# Altium schematic sheet DE-100224_01.SchDoc(DE-100224_01)
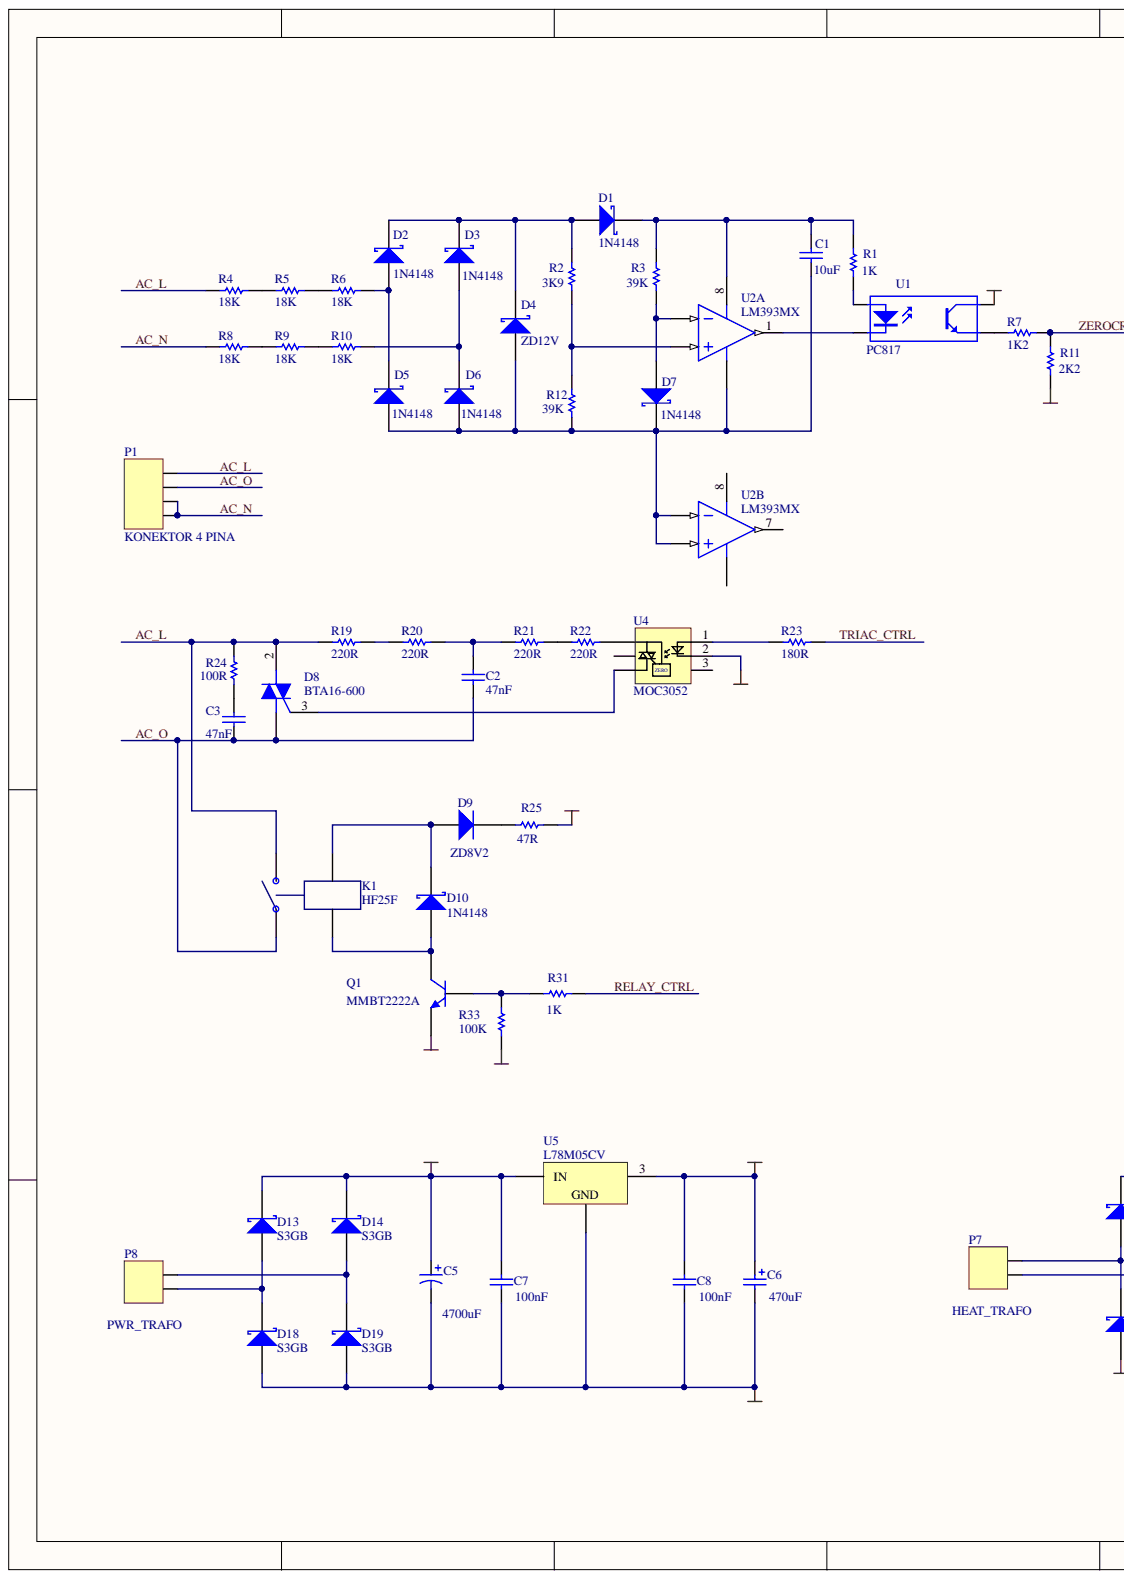
[diagram p0: DE-100224_01.SchDoc, left]
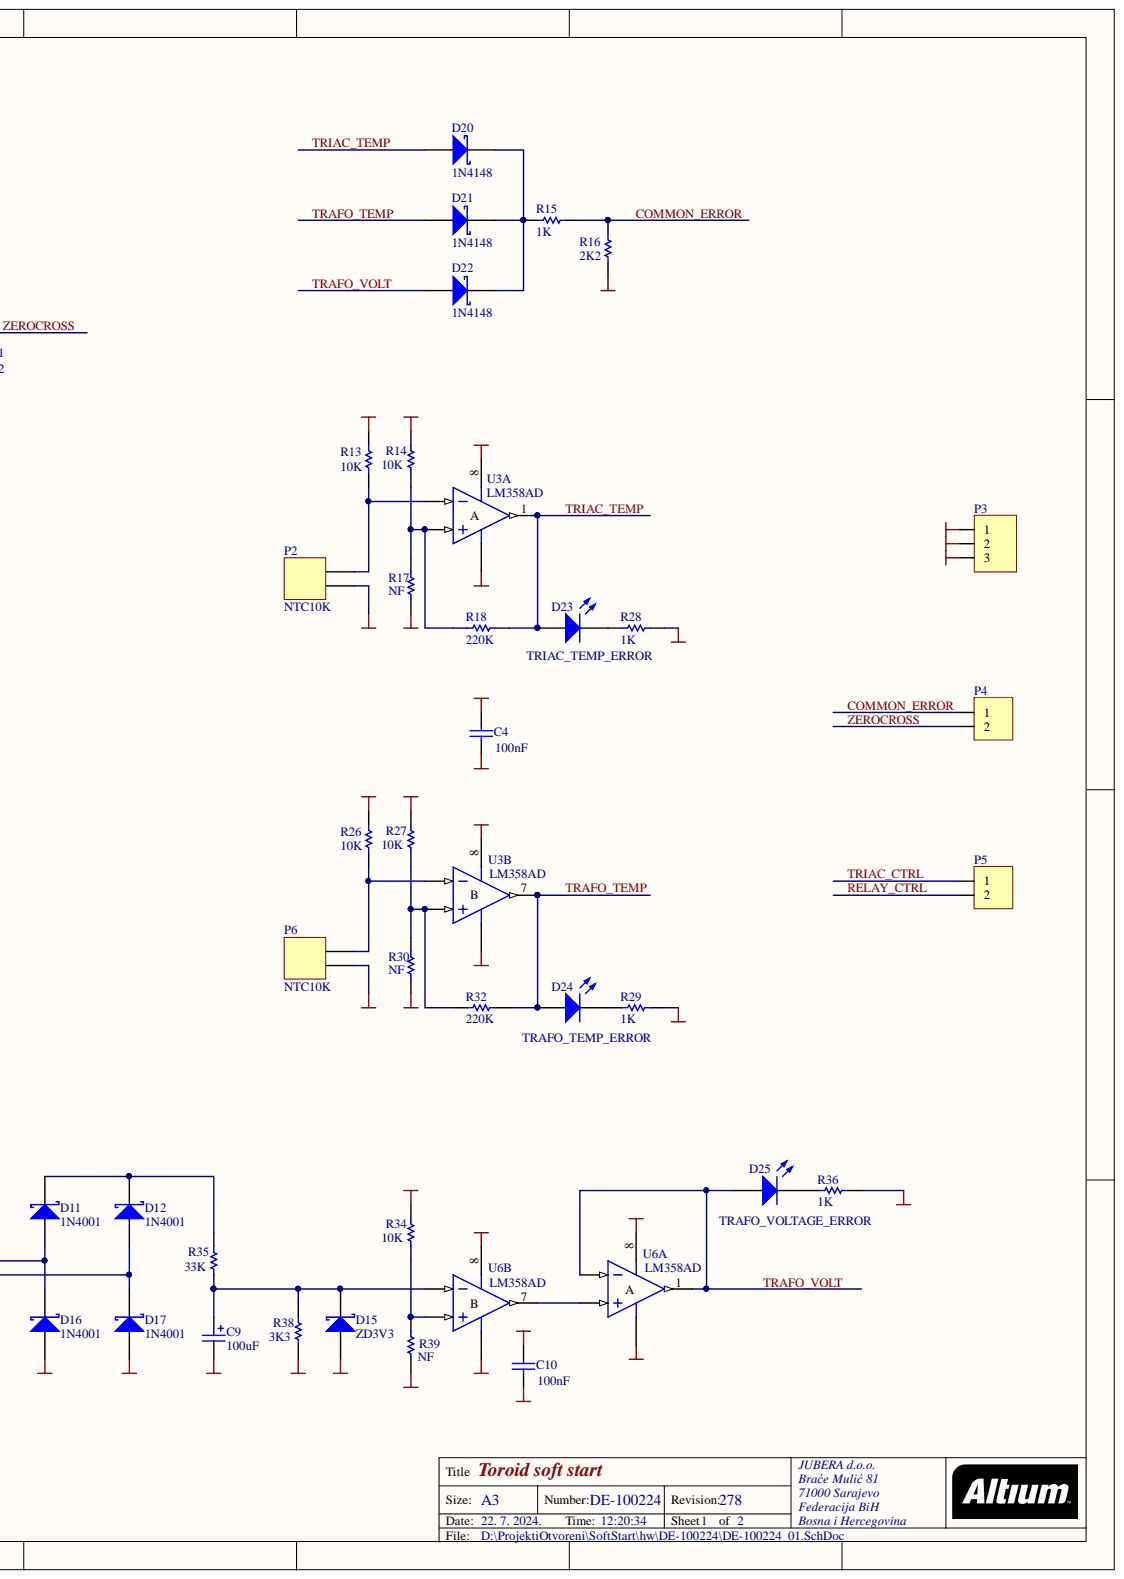
[diagram p1: DE-100224_01.SchDoc, right]

## Parts
C1  10uF  [0805]
C2  47nF  [X2 CAP]
C3  47nF  [X2 CAP]
C4  100nF  [0603]
C5  4700uF  [CAPPR5-13X25]
C6  470uF  [CAPPR5-10X16]
C7  100nF  [0603]
C8  100nF  [0603]
C9  100uF  [CAPPR2-5X11]
C10  100nF  [0603]
D1  1N4148  [SOD323]
D2  1N4148  [SOD323]
D3  1N4148  [SOD323]
D4  ZD12V  [SMB]
D5  1N4148  [SOD323]
D6  1N4148  [SOD323]
D7  1N4148  [SOD323]
D8  BTA16-600  [ONSC-TO-220-3-221A-07]
D9  ZD8V2  [DO-41]
D10  1N4148  [SOD323]
D11  1N4001  [SMB]
D12  1N4001  [SMB]
D13  S3GB  [SMB]
D14  S3GB  [SMB]
D15  ZD3V3  [SOD323]
D16  1N4001  [SMB]
D17  1N4001  [SMB]
D18  S3GB  [SMB]
D19  S3GB  [SMB]
D20  1N4148  [SOD323]
D21  1N4148  [SOD323]
D22  1N4148  [SOD323]
D23  TRIAC_TEMP_ERROR  [1.6X0.8X0.8]
D24  TRAFO_TEMP_ERROR  [1.6X0.8X0.8]
D25  TRAFO_VOLTAGE_ERROR  [1.6X0.8X0.8]
K1  HF25F  [HF25F]
P1  KONEKTOR 4 PINA  [KONEKTOR 4 PINA]
P2  NTC10K  [HDR1X2]
P3  (no value)  [TERMINAL BLOK 3]
P4  (no value)  [TERMINAL BLOK 2]
P5  (no value)  [TERMINAL BLOK 2]
P6  NTC10K  [HDR1X2]
P7  HEAT_TRAFO  [KONEKTOR 2 PINA]
P8  PWR_TRAFO  [KONEKTOR 2 PINA]
Q1  MMBT2222A  [SOT23_N]
R1  1K  [ERA-0603]
R2  3K9  [ERA-0603]
R3  39K  [ERA-0603]
R4  18K  [ERA-0805]
R5  18K  [ERA-0805]
R6  18K  [ERA-0805]
R7  1K2  [ERA-0603]
R8  18K  [ERA-0805]
R9  18K  [ERA-0805]
R10  18K  [ERA-0805]
R11  2K2  [ERA-0603]
R12  39K  [ERA-0603]
R13  10K  [ERA-0603]
R14  10K  [ERA-0603]
R15  1K  [ERA-0603]
R16  2K2  [ERA-0603]
R17  NF  [ERA-0603]
R18  220K  [ERA-0603]
R19  220R  [ERA-0805]
R20  220R  [ERA-0805]
R21  220R  [ERA-0805]
R22  220R  [ERA-0805]
R23  180R  [ERA-0603]
R24  100R  [1W100R]
R25  value 47R  [AXIAL-0.3]  (hidden comment: Res1)
R26  10K  [ERA-0603]
R27  10K  [ERA-0603]
R28  1K  [ERA-0603]
R29  1K  [ERA-0603]
R30  NF  [ERA-0603]
R31  1K  [ERA-0603]
R32  220K  [ERA-0603]
R33  100K  [ERA-0603]
R34  10K  [ERA-0603]
R35  33K  [ERA-0603]
R36  1K  [ERA-0603]
R38  3K3  [ERA-0603]
R39  NF  [ERA-0603]
U1  PC817  [DIP-4]
U2  LM393MX  [M08A_N]  (parts A,B)
U3  LM358AD  [SO8_N]  (parts A,B)
U4  MOC3052  [DIP6]
U5  L78M05CV  [TO220]
U6  LM358AD  [SO8_N]  (parts A,B)

## Nets
+5V: C4.2 C6.1 C8.2 C10.2 P3.1 R13.2 R14.2 R26.2 R27.2 R34.2 U1.4 U3.8 U5.3 U6.8
+24V: C5.1 C7.2 D13.2 D14.2 P3.3 R25.2 U5.1
GND: C4.1 C5.2 C6.2 C7.1 C8.1 C9.2 C10.1 D15.2 D16.1 D17.1 D18.1 D19.1 P2.1 P3.2 P6.1 Q1.2 R11.1 R16.1 R17.1 R28.1 R29.1 R30.1 R33.1 R36.1 R38.1 R39.1 U3.4 U4.2 U5.2 U6.4
AC_L: D8.2 K1.4 P1.1 R4.1 R19.1 R24.2
AC_N: P1.3 P1.4 R8.1
AC_O: C2.1 C3.1 D8.1 K1.3 P1.2
COMMON_ERROR: P4.1 R15.1 R16.2
RELAY_CTRL: P5.2 R31.1
TRAFO_TEMP: D21.2 D24.1 R32.1 U3.7
TRAFO_VOLT: D22.2 D25.1 U6.1 U6.2
TRIAC_CTRL: P5.1 R23.1
TRIAC_TEMP: D20.2 D23.1 R18.1 U3.1
ZEROCROSS: P4.2 R7.1 R11.2
NetC1_1: C1.1 D4.1 D5.2 D6.2 D7.1 R12.1 U2.4 U2.5 U2.6
NetC1_2: C1.2 D1.1 R1.2 R3.2 U2.8
NetC2_2: C2.2 R20.2 R21.1
NetC3_2: C3.2 R24.1
NetC9_1: C9.1 D15.1 R35.1 R38.2 U6.6
NetD1_2: D1.2 D2.1 D3.1 D4.2 R2.2
NetD2_2: D2.2 D5.1 R6.2
NetD3_2: D3.2 D6.1 R10.2
NetD7_2: D7.2 R3.1 U2.2
NetD8_3: D8.3 U4.4
NetD9_1: D9.1 D10.1 K1.2
NetD9_2: D9.2 R25.1
NetD10_2: D10.2 K1.1 Q1.3
NetD11_1: D11.1 D16.2 P7.2
NetD11_2: D11.2 D12.2 R35.2
NetD12_1: D12.1 D17.2 P7.1
NetD13_1: D13.1 D18.2 P8.1
NetD14_1: D14.1 D19.2 P8.2
NetD20_1: D20.1 D21.1 D22.1 R15.2
NetD23_2: D23.2 R28.2
NetD24_2: D24.2 R29.2
NetD25_2: D25.2 R36.2
NetP2_2: P2.2 R13.1 U3.2
NetP6_2: P6.2 R26.1 U3.6
NetQ1_1: Q1.1 R31.2 R33.2
NetR1_1: R1.1 U1.1
NetR2_1: R2.1 R12.2 U2.3
NetR4_2: R4.2 R5.1
NetR5_2: R5.2 R6.1
NetR7_2: R7.2 U1.3
NetR8_2: R8.2 R9.1
NetR9_2: R9.2 R10.1
NetR14_1: R14.1 R17.2 R18.2 U3.3
NetR19_2: R19.2 R20.1
NetR21_2: R21.2 R22.1
NetR22_2: R22.2 U4.6
NetR23_2: R23.2 U4.1
NetR27_1: R27.1 R30.2 R32.2 U3.5
NetR34_1: R34.1 R39.2 U6.5
NetU1_2: U1.2 U2.1
NetU6_3: U6.3 U6.7

Not connected: U2 7; U4 3 5

## Library data of the parts
C1 = C0805: Ceramic Chip Capacitor - Standard; Note: Footprint corresponds to dimensions for bulk cassette packaging. This case size has tightened tolerances.; Value: 10uF; Simulation: CAP; Signal Integrity: C0805; Published: 17-Jul-2002; PackageReference: 0805; PackageDocument: Mar-2001; Library Name: DE-100224.SchLib; LatestRevisionNote: Silkscreen size reduced.; LatestRevisionDate: 19-Mar-2004; DatasheetDocument: Mar-2001; ComponentLink2URL: http://www.kemet.com/kemet/web/homepage/kechome.nsf/vapubfilesname/F3102TA.pdf/$file/F3102TA.pdf; ComponentLink2Description: Datasheet; ComponentLink1URL: http://www.kemet.com/; ComponentLink1Description: Manufacturer Link; Component Kind: Standard; Code_JEITA: 0805; Code_IPC: 2012[0805]; Publisher: Altium Limited
C2 C3 = CAP X2: X2 275VAC capaitor; Library Name: DE-100224.SchLib; Component Kind: Standard
C4 C7 C8 C10 = C0603: Ceramic Chip Capacitor - Standard; Note: Footprint corresponds to dimensions for bulk cassette packaging. This case size has tightened tolerances.; Value: 100nF; Simulation: CAP; Signal Integrity: C0603; Published: 17-Jul-2002; PackageReference: 0603; PackageDocument: Mar-2001; Library Name: DE-100224.SchLib; LatestRevisionNote: Silkscreen size reduced.; LatestRevisionDate: 19-Mar-2004; DatasheetDocument: Mar-2001; ComponentLink2URL: http://www.kemet.com/kemet/web/homepage/kechome.nsf/vapubfilesname/F3102TA.pdf/$file/F3102TA.pdf; ComponentLink2Description: Datasheet; ComponentLink1URL: http://www.kemet.com/; ComponentLink1Description: Manufacturer Link; Component Kind: Standard; Code_JEITA: 0603; Code_IPC: 1608 [0603]; Publisher: Altium Limited
C5 = EKA00GG447C00: Aluminum Electrolytic Capacitor, Radial Style with Long Leads: 4700uF, 10V; Note: Refer to Manufacturer's Data Sheets for other lead formations; DrillSize: Nominal, Rounded To The Nearest 0.1mm; Value: 4700uF; Simulation: CAP; Signal Integrity: EKA00GG447C00; Published: 19-Mar-2004; PackageReference: CAPPR5-13X25; DatasheetDocument: 20-Feb-2001; ComponentLink2URL: http://www.vishay.com/docs/25014/eka.pdf; ComponentLink2Description: Datasheet; ComponentLink1URL: http://www.vishay.com/; ComponentLink1Description: Manufacturer Link; Component Kind: Standard; Publisher: Altium Limited; Library Name: Vishay Roederstein Electrolytic Radial-Lead Capacitor.IntLib
C6 = EKA00DD347F00: Aluminum Electrolytic Capacitor, Radial Style with Long Leads: 470uF, 35V; Note: Refer to Manufacturer's Data Sheets for other lead formations; DrillSize: Nominal, Rounded To The Nearest 0.1mm; Value: 470uF; Simulation: CAP; Signal Integrity: EKA00DD347F00; Published: 19-Mar-2004; PackageReference: CAPPR5-10X16; Library Name: DE-100224.SchLib; DatasheetDocument: 20-Feb-2001; ComponentLink2URL: http://www.vishay.com/docs/25014/eka.pdf; ComponentLink2Description: Datasheet; ComponentLink1URL: http://www.vishay.com/; ComponentLink1Description: Manufacturer Link; Component Kind: Standard; Publisher: Altium Limited
C9 = EKA00AA247F00: Aluminum Electrolytic Capacitor, Radial Style with Long Leads: 47uF, 35V; Note: Refer to Manufacturer's Data Sheets for other lead formations; DrillSize: Nominal, Rounded To The Nearest 0.1mm; Value: 47uF; Simulation: CAP; Signal Integrity: EKA00AA247F00; Published: 19-Mar-2004; PackageReference: CAPPR2-5X11; Library Name: DE-100224.SchLib; DatasheetDocument: 20-Feb-2001; ComponentLink2URL: http://www.vishay.com/docs/25014/eka.pdf; ComponentLink2Description: Datasheet; ComponentLink1URL: http://www.vishay.com/; ComponentLink1Description: Manufacturer Link; Component Kind: Standard; Publisher: Altium Limited
D1-D3 D5-D7 D10 D15 D20-D22 = 1PS76SB10: Schottky Barrier Diode; Simulation: D1PS76SBD10; Signal Integrity: 1PS76SB10; Published: 17-Sep-2003; PackageVersion: May-1999; PackageReference: SOD323; PackageDescription: SOD-323/SC-76; 2 Leads; Body 1.7 x 1.3 mm, (LxW); Library Name: DE-100224.SchLib; LatestRevisionNote: Silkscreen size reduced.; LatestRevisionDate: 19-Mar-2004; DatasheetVersion: 14-Oct-1996; ComponentLink2URL: http://www.semiconductors.philips.com/acrobat/datasheets/1PS76SB10_2.pdf; ComponentLink2Description: Datasheet; ComponentLink1URL: http://www.semiconductors.philips.com/; ComponentLink1Description: Manufacturer Link; Component Kind: Standard; Publisher: Altium Limited
D4 = 10BQ100: Schottky Rectifier; Simulation: 10BQ100; Signal Integrity: 10BQ100; Published: 8-Jun-2000; PackageReference: SMB; PackageDescription: DO-214-AA/SMB; 2 C-Bend Leads; Body 5.3 x 3.6 mm, inc. leads (LxW); Library Name: DE-100224.SchLib; LatestRevisionNote: Re-released for DXP Platform.; LatestRevisionDate: 17-Jul-2002; DatasheetVersion: PD-2.437A; ComponentLink2URL: http://www.irf.com/product-info/datasheets/data/10bq100.pdf; ComponentLink2Description: Datasheet; ComponentLink1URL: http://www.irf.com/; ComponentLink1Description: Manufacturer Link; Component Kind: Standard; Publisher: Altium Limited
D8 = BTA08-600BW3G: Triacs, Silicon Bidirectional Thyristor, 3-Pin TO-220, Pb-Free, Tube; Manufacturer: On Semiconductor; ITSM Max (A): 90; IT(RMS) Max (A): 8; IGT Max (mA): 50; Supplier Part Number 3: 863-BTA08-600BW3G; Supplier Part Number 2: 13P2072; Supplier Part Number 1: BTA08-600BW3G-ND; Supplier 3: Mouser; Supplier 2: Newark; Supplier 1: Digi-Key; RoHS: Pb-Free; PartNumber: BTA08-600BW3G; Packing: Tube; PackageVersion: Rev. O, 07/2008; PackageReference: TO-220-3-221A-07; PackageDescription: 3-Pin Flange Mount, Vertical Straight Lead, Body 9.97 x 4.445 mm, Pitch 2.54 mm; Mounting Technology: Through Hole; Library Name: DE-100224.SchLib; DatasheetVersion: Rev. 1; ComponentLink3URL: http://www.onsemi.com/pub_link/Collateral/221A-07.PDF; ComponentLink3Description: Package Specification; ComponentLink2URL: http://www.onsemi.com/pub_link/Collateral/BTA08-600BW3-D.PDF; ComponentLink2Description: Datasheet; ComponentLink1URL: http://www.onsemi.com/; ComponentLink1Description: Manufacturer URL; Component Kind: Standard
D9 = 1N4003: 1.0 Ampere General Purpose Rectifier; Simulation: 1N4003; Signal Integrity: 1N4003; Published: 8-Jun-2000; PackageReference: DO-41; PackageDocument: Aug-1999; Library Name: DE-100224.SchLib; LatestRevisionNote: Re-released for DXP Platform.; LatestRevisionDate: 17-Jul-2002; DatasheetDocument: 1998; ComponentLink2URL: http://www.fairchildsemi.com/ds/1N%2F1N4001.pdf; ComponentLink2Description: Pinout Specification; ComponentLink1URL: http://www.fairchildsemi.com/; ComponentLink1Description: Manufacturer Link; Component Kind: Standard; Code_JEDEC: DO-204-AL; Publisher: Altium Limited
D11-D14 D16-D19 = D Schottky: Schottky Diode; Simulation: SKYDIODE; Signal Integrity: D Schottky; Published: 8-Jun-2000; PackageReference: SMB; PackageDescription: DO-214-AA/SMB; 2 C-Bend Leads; Body 5.3 x 3.6 mm, inc. leads (LxW); Library Name: Miscellaneous Devices.IntLib; LatestRevisionNote: Re-released for DXP Platform.; LatestRevisionDate: 17-Jul-2002; Component Kind: Standard; Code_JEDEC: DO-214-AA; Publisher: Altium Limited
D23-D25 = HSMB-C190: Blue Surface Mount Chip LED, Blue; PCB_Layout: Complies with manufacturer's recommendation.; LuminousIntensity: 1.6mcd (Minimum).; Simulation: Agl_HSMBC110; Signal Integrity: LED; Published: 11-Dec-2002; PackageVersion: Mar-2002; PackageReference: 1.6X0.8X0.8; PackageDescription: SM LED; 2 Flat Leads; Library Name: DE-100224.SchLib; LED_Colour: Blue; DatasheetVersion: 26-Feb-2002; ComponentLink2URL: http://cp.literature.agilent.com/litweb/pdf/5988-5211EN.pdf; ComponentLink2Description: Datasheet; ComponentLink1URL: http://www.avagotech.com/; ComponentLink1Description: Manufacturer Link; Component Kind: Standard; Publisher: Altium Limited
K1 = HF25F: PCB relay 250VAC/20A 30VDC/16A; Library Name: DE-100224.SchLib; Component Kind: Standard
P1 = KONEKTOR 4 PINA: Razdvojivi konektor 4 pina; Library Name: DE-100224.SchLib; Component Kind: Standard
P2 P6 = Header 2: Header, 2-Pin; Signal Integrity: Connector; Library Name: Miscellaneous Connectors.IntLib; LatestRevisionNote: Re-released for DXP Platform.; LatestRevisionDate: 17-Jul-2002; Component Kind: Standard; Publisher: Altium Limited
P3 = TERMINAL BLOK 3: 3 pinski terminal blok konektor; Library Name: DE-100224.SchLib; Component Kind: Standard
P4 P5 = TERMINAL BLOK 2: Terminal blok konektor 3,5 mm; Library Name: DE-100224.SchLib; Component Kind: Standard
P7 P8 = KONEKTOR 2 PINA: Razdvojivi konetor 2 pina; Library Name: DE-100224.SchLib; Component Kind: Standard
Q1 = BC817: NPN General-purpose Transistor; Simulation: QBC817; Signal Integrity: BC817; Published: 17-Sep-2003; PackageVersion: May-1999; PackageReference: SOT23; PackageDescription: 3-Pin SOT-23 Package 0.95 mm Pitch, 2.3 mm Lead Span; Library Name: DE-100224.SchLib; LatestRevisionNote: IPC-7351 Footprint Added.; LatestRevisionDate: 12-Dec-2006; DatasheetVersion: Jun-1999; ComponentLink2URL: http://www.semiconductors.philips.com/acrobat/datasheets/BC817_BC817W_BC337_5.pdf; ComponentLink2Description: Datasheet; ComponentLink1URL: http://www.semiconductors.philips.com/; ComponentLink1Description: Manufacturer Link; Component Kind: Standard; Publisher: Altium Limited
R1-R3 R7 R11-R18 R23 R26-R36 R38 R39 = ERAV: Metal Film Thermosensitive Chip Resistor, 0.56 Ohm to 10k Ohm Range, 5% Tolerance, 0603 Size, 0.063 W; Note: If flow soldering, adjust pad width of PCB Footprint to 0.7 - 0.8 times the width of the resistor.; Simulation: RESISTOR; Signal Integrity: Res; Published: 17-Dec-2003; PackageReference: ERA-0603; PackageDocument: Mar-2003; Library Name: DE-100224.SchLib; LatestRevisionNote: Silkscreen size reduced.; LatestRevisionDate: 19-Mar-2004; ComponentLink2URL: http://www.panasonic.com/industrial/components/pdf/AOF0000CE1.pdf; ComponentLink2Description: Datasheet; ComponentLink1URL: http://www.panasonic.com/industrial/components/resistive_products.htm; ComponentLink1Description: Manufacturer Link; Component Kind: Standard; Code_IPC: 1608 [0603]; Publisher: Altium Limited
R4-R6 R8-R10 R19-R22 = ERAS: Metal Film Thermosensitive Chip Resistor, 0.56 Ohm to 10k Ohm Range, 5% Tolerance, 0805 Size, 0.1 W; Note: If flow soldering, adjust pad width of PCB Footprint to 0.7 - 0.8 times the width of the resistor.; Simulation: RESISTOR; Signal Integrity: Res; Published: 17-Dec-2003; PackageReference: ERA-0805; PackageDocument: Mar-2003; Library Name: DE-100224.SchLib; LatestRevisionNote: Silkscreen size reduced.; LatestRevisionDate: 19-Mar-2004; ComponentLink2URL: http://www.panasonic.com/industrial/components/pdf/AOF0000CE1.pdf; ComponentLink2Description: Datasheet; ComponentLink1URL: http://www.panasonic.com/industrial/components/resistive_products.htm; ComponentLink1Description: Manufacturer Link; Component Kind: Standard; Code_IPC: 2012 [0805]; Publisher: Altium Limited
R24 = 1W100R: Carbon F 1W resistor; Published: 17-Dec-2003; PackageReference: RD6.35x2.3; Library Name: DE-100224.SchLib; ComponentLink2URL: http://www.panasonic.com/industrial/components/pdf/AOA0000CE12.pdf; ComponentLink2Description: Datasheet; ComponentLink1URL: http://www.panasonic.com/industrial/components/resistive_products.htm; ComponentLink1Description: Manufacturer Link; Component Kind: Standard; Publisher: Altium Limited
R25 = Res1: Resistor; Simulation: RESISTOR; Signal Integrity: Res; Published: 8-Jun-2000; PackageReference: AXIAL-0.3; PackageDescription: Axial Device, Thru-Hole; 2 Leads; 0.3 in Pin Spacing; Library Name: Miscellaneous Devices.IntLib; LatestRevisionNote: Re-released for DXP Platform.; LatestRevisionDate: 17-Jul-2002; Component Kind: Standard; Publisher: Altium Limited
U1 = PC817: 4-Pin Phototransistor Optocoupler; Published: 24-Mar-1999; PackageReference: DIP-4; Library Name: DE-100224.SchLib; LatestRevisionNote: Sch pins 3 & 4 Swapped.; LatestRevisionDate: 04-Mar-2005; DatasheetVersion: Nov-2003; Component Kind: Standard; Publisher: Altium Limited
U2 = LM393MX: Low Power Low Offset Voltage Dual Comparator, 8-pin Narrow SOIC; Manufacturer: National Semiconductor; PowerWise: N; PackageTopMarking: NSZXTT*LM*393M; High-Reliability: Y; RoHS: N; PinCount: 8; PartNumber: LM393MX; PackageVersion: revM, May-2009; PackageReference: M08A; PackageDescription: SOIC Narrow, 4.9 x 3.9 x 1.45mm, 8 Lead, 1.27mm Pitch; Library Name: National Semiconductor Comparator.IntLib; DatasheetVersion: Aug-2002; CompType: Comparator; ComponentLink4URL: http://www.national.com/packaging/folders/m08a.html; ComponentLink4Description: M08A Package Page; ComponentLink3URL: http://www.national.com/pf/LM/LM393.html; ComponentLink3Description: LM393 Web Page; ComponentLink2URL: http://www.national.com/ds/LM/LM393.pdf; ComponentLink2Description: LM393 Datasheet; ComponentLink1URL: http://www.national.com/; ComponentLink1Description: Manufacturer URL; Component Kind: Standard; Code_JEDEC: MS-012-AA; Code_IPC: SOIC127P600-8
U3 U6 = LM358AD: Low-Power Dual Operational Amplifier; Simulation: LM358; Published: 24-Aug-2001; PCB3D: SO8; PackageVersion: Dec-2002; PackageReference: SO8; PackageDescription: 8-Pin Small Outline Integrated Circuit 1.27 mm Pitch; Library Name: ST Operational Amplifier.IntLib; LatestRevisionNote: IPC-7351 Footprint Added.; LatestRevisionDate: 13-Apr-2006; DatasheetVersion: Jun-1998; ComponentLink2URL: http://www.st.com/stonline/products/literature/ds/2163/lm358.pdf; ComponentLink2Description: Datasheet; ComponentLink1URL: http://www.st.com/; ComponentLink1Description: Manufacturer Link; Component Kind: Standard; Code_JEDEC: MS-012-AA; Code_IPC: SOIC127P600-8; Publisher: Altium Limited
U4 = MOC3081: Zero-Cross Optoisolator Triac Driver; Library Name: DE-100224.SchLib; Component Kind: Standard
U5 = L78M05CV: Positive Voltage Regulator, 5V, 3-Pin TO-220; PartNumber: L78M05CV; PackageVersion: ver3.0; PackageReference: TO220; PackageDescription: TO, Thru-Hole, Vertical, Heatsink Mounted; 3 In-Line Leads; Pitch 2.54 mm; Library Name: STMicroelectronics Power Management Linear Regulator.IntLib; DatasheetVersion: Nov-2010; ComponentLink3URL: http://www.st.com/internet/com/TECHNICAL_RESOURCES/TECHNICAL_LITERATURE/PACKAGE_INFORMATION/CD00004649.pdf; ComponentLink3Description: Package Specification; ComponentLink2URL: http://www.st.com/internet/com/TECHNICAL_RESOURCES/TECHNICAL_LITERATURE/DATASHEET/CD00000447.pdf; ComponentLink2Description: Datasheet; ComponentLink1URL: http://www.st.com; ComponentLink1Description: Manufacturer URL; Component Kind: Standard
